# Altium schematic sheet AWS PSU.SchDoc
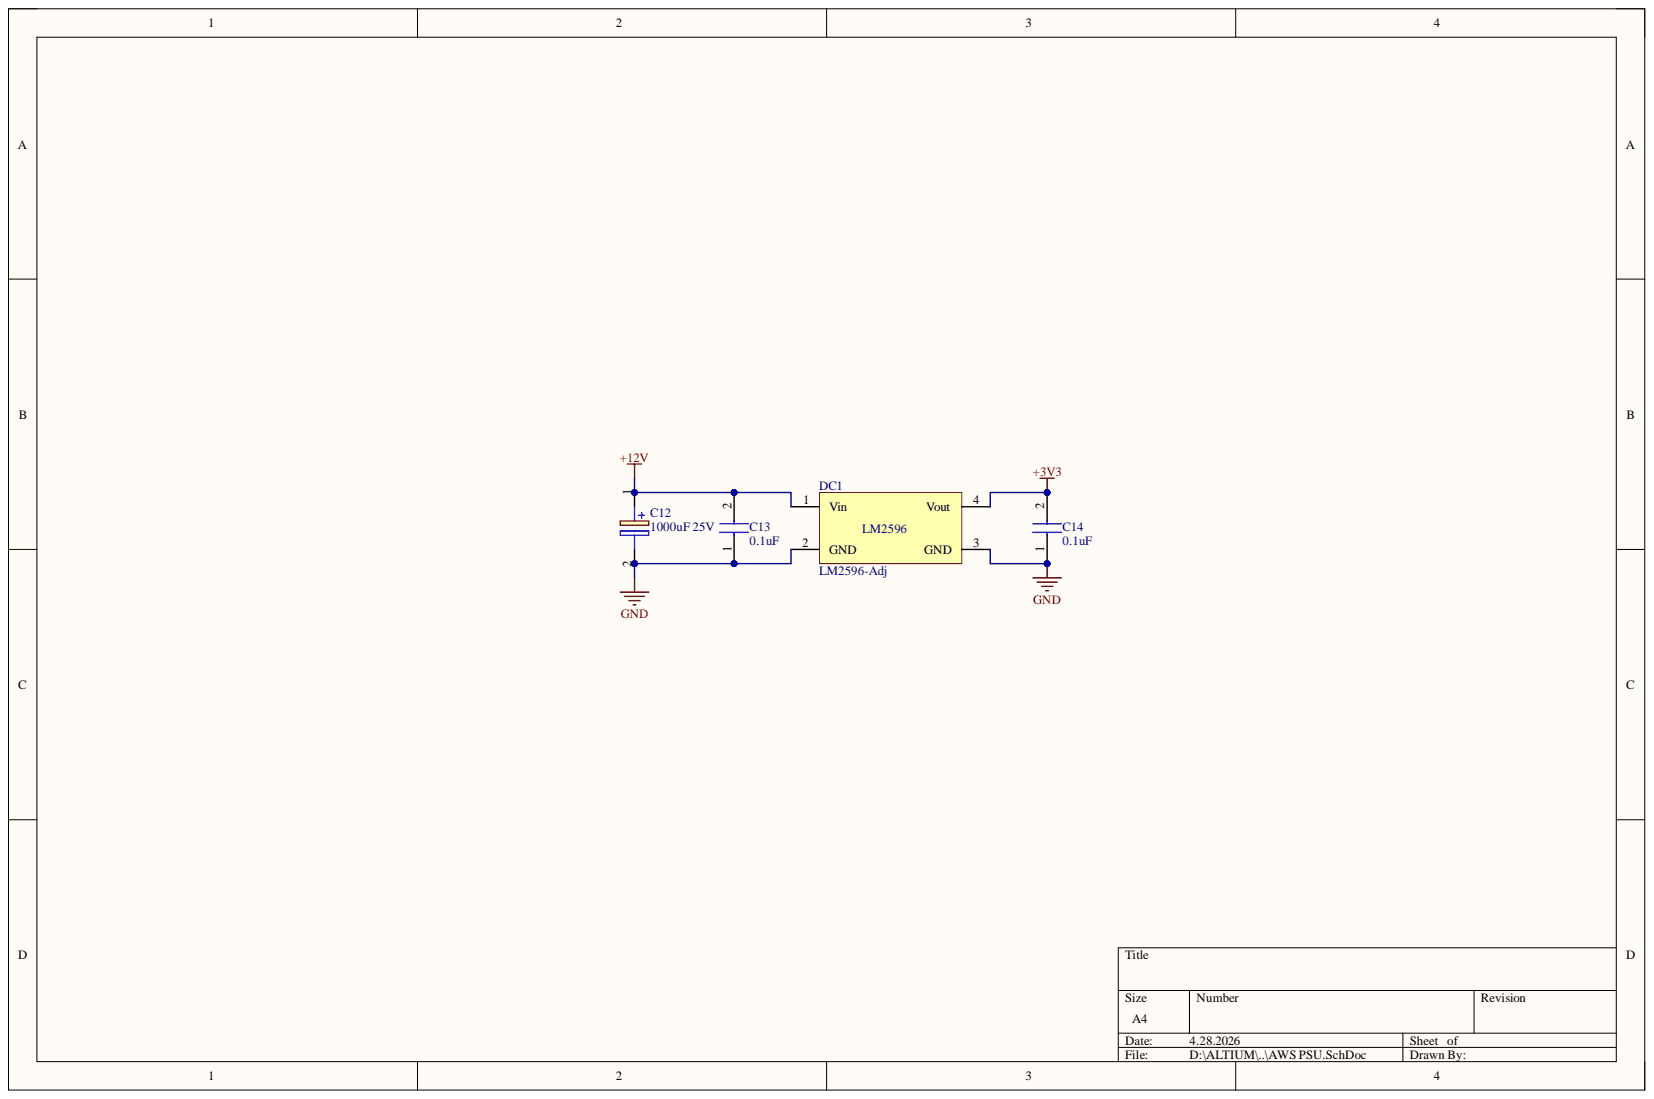
[diagram p0: AWS PSU.SchDoc]

## Parts
C12  1000uF 25V  [CAPPRD750W85D1600H1700]
C13  0.1uF  [FG18C0G1H101JNT06]
C14  0.1uF  [FG18C0G1H101JNT06]
DC1  LM2596-Adj  [lm2596]

## Nets
+3V3: C14.2 DC1.4(Vout)
+12V: C12.1 C13.2 DC1.1(Vin)
GND: C12.2 C13.1 C14.1 DC1.2(GND) DC1.3(GND)

## Library data of the parts
C12 = EEU-FC1H102: Capacitor Polarised; Manufacturer_Name: Panasonic; Arrow Part Number: EEU-FC1H102; Arrow Price/Stock: https://www.arrow.com/en/products/eeu-fc1h102/panasonic?utm_currency=USD&region=nac; Component Kind: Standard; Datasheet Link: http://industrial.panasonic.com/cdbs/www-data/pdf/RDF0000/ABA0000C1209.pdf; Height: 17mm; Manufacturer_Part_Number: EEU-FC1H102; Mouser Part Number: 667-EEU-FC1H102; Mouser Price/Stock: https://www.mouser.co.uk/ProductDetail/Panasonic/EEU-FC1H102?qs=qGjfa%2FQGa0vLrwehN7bT4w%3D%3D
C13 C14 = FG18X7R1H104KNT06: Capacitor; Manufacturer_Name: TDK; Arrow Part Number: FG18X7R1H104KNT06; Arrow Price/Stock: https://www.arrow.com/en/products/fg18x7r1h104knt06/tdk; Component Kind: Standard; Datasheet Link: https://product.tdk.com/system/files/dam/doc/product/capacitor/ceramic/lead-mlcc/catalog/leadmlcc_halogenfree_fg_en.pdf; Height: 5.5mm; Manufacturer_Part_Number: FG18X7R1H104KNT06; Mouser Part Number: 810-FG18X7R1H104KNT6; Mouser Price/Stock: https://www.mouser.co.uk/ProductDetail/TDK/FG18X7R1H104KNT06?qs=qf2ddTMq67WA8sH6FratLA%3D%3D
DC1 = LM2596-Adj: Designed By @Alixahedi; Component Kind: Standard; Library Name: LM2596-Adj.IntLib
